# Altium schematic sheet Main.SchDoc
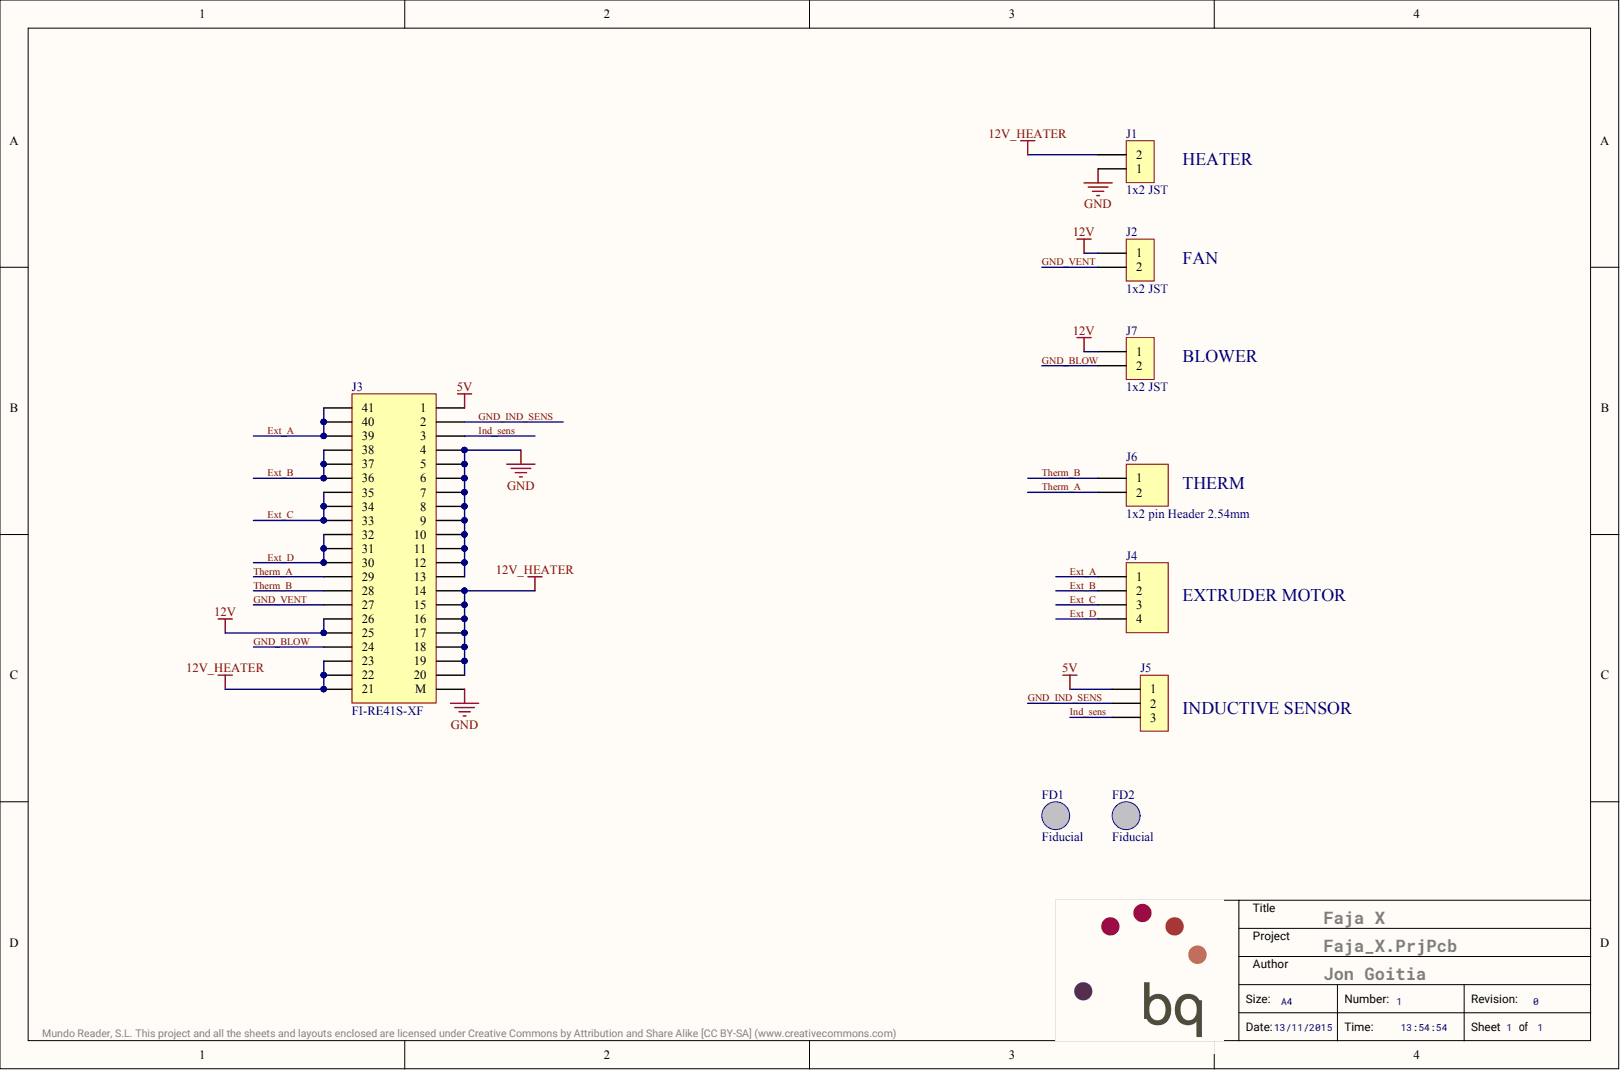
[diagram p0: Main.SchDoc]

## Parts
FD1  Fiducial  [Fiducial_1mm]  (no BOM)
FD2  Fiducial  [Fiducial_1mm]  (no BOM)
J1  1x2 JST  Manufacturer: JST  [SM02B-SFHRS-TF]
J2  1x2 JST  Manufacturer: JST  [SM02B-SFHRS-TF]
J3  FI-RE41S-XF  [FI-RE41S-HF]
J4  value 4  [1x4 Header Angled SMD 2.54]  (hidden comment: 1x4 Pin Angled Header 2.54mm)
J5  1x3 Socket Header 2.54mm  [SSW-103-22-F-S-VS]  (value not drawn)
J6  1x2 pin Header 2.54mm  value 2  [1x2 Pin Header 2.54]
J7  1x2 JST  Manufacturer: JST  [SM02B-SFHRS-TF]

## Nets
5V: J3.1 J5.1
12V: J2.1 J3.25 J3.26 J7.1
12V_HEATER: J1.2 J3.14 J3.15 J3.16 J3.17 J3.18 J3.19 J3.20 J3.21 J3.22 J3.23
GND: J1.1 J3.4 J3.5 J3.6 J3.7 J3.8 J3.9 J3.10 J3.11 J3.12 J3.13 J3.M
EXT_A: J3.39 J3.40 J3.41 J4.1
EXT_B: J3.36 J3.37 J3.38 J4.2
EXT_C: J3.33 J3.34 J3.35 J4.3
EXT_D: J3.30 J3.31 J3.32 J4.4
GND_BLOW: J3.24 J7.2
GND_IND_SENS: J3.2 J5.2
GND_VENT: J2.2 J3.27
IND_SENS: J3.3 J5.3
THERM_A: J3.29 J6.2
THERM_B: J3.28 J6.1

## Library data of the parts
FD1 FD2 = Fiducial 1mm: Fiducial Mark; Database Table Name: Fiducial$; Part Number: Fiducial 1mm; Library Name: Miscelanea.SVNDbLib
J1 J2 J7 = SM02B-SFHRS-TF: Connector, 2 pin JST; Current: 4A (AWG#22); Database Table Name: Power$; Temp_ Max: 85; Temp_ Min: -25; Component Kind: Standard; Library Name: Connectors_JST.SVNDbLib; OrderingCode: SM02B-SFHRS-TF; Part Number: SM02B-SFHRS-TF
J3 = FI-RE41S-HF: Manufacturer: JAE; Database Table Name: Flex$; Component Kind: Standard; Library Name: Connectors_Flex.SVNDbLib; OrderingCode: FI-RE41S-HF; Part Number: FI-RE41S-HF
J4 = CON_TPH_SMDA2.54_4: Connector, 1x4 Pin Angled Header 2.54mm; Manufacturer: Samtec; Database Table Name: Con_Angled_Header_SMD_2#54$; OrderingCode: TSM-104-01-T-SH; Temp_ Max: 105; Temp_ Min: -55; Component Kind: Standard; Library Name: Conectores_PinHeader_2.54.SVNDbLib; Part Number: CON_TPH_SMDA2.54_4
J5 = CON_SH_SMD_2.54_3: Connector, 3 pin Socket Header 2.54mm; Manufacturer: Samtec; Database Table Name: Con_Socket_Header_SMD_2#54$; Temp_ Max: 125; Temp_ Min: -40; Component Kind: Standard; Library Name: Conectores_SocketHeader_2.54.SVNDbLib; OrderingCode: SSW-103-22-F-S-VS; Part Number: CON_SH_SMD_2.54_3
J6 = CON_PH_THT2.54_2: Connector, 1x2 pin Header 2.54mm; Manufacturer: Samtec; Database Table Name: Con_Pin_Header_THT_2#54$; OrderingCode: TSW-102-07-T-S; OrderingCode 2: 613 002 111 21; Temp_ Max: 105; Temp_ Min: -55; Component Kind: Standard; Library Name: Conectores_PinHeader_2.54.SVNDbLib; Manufacturer 2: Würth Elektronik; Part Number: CON_PH_THT2.54_2
